# Altium schematic sheet VS1053DEMO.SchDoc
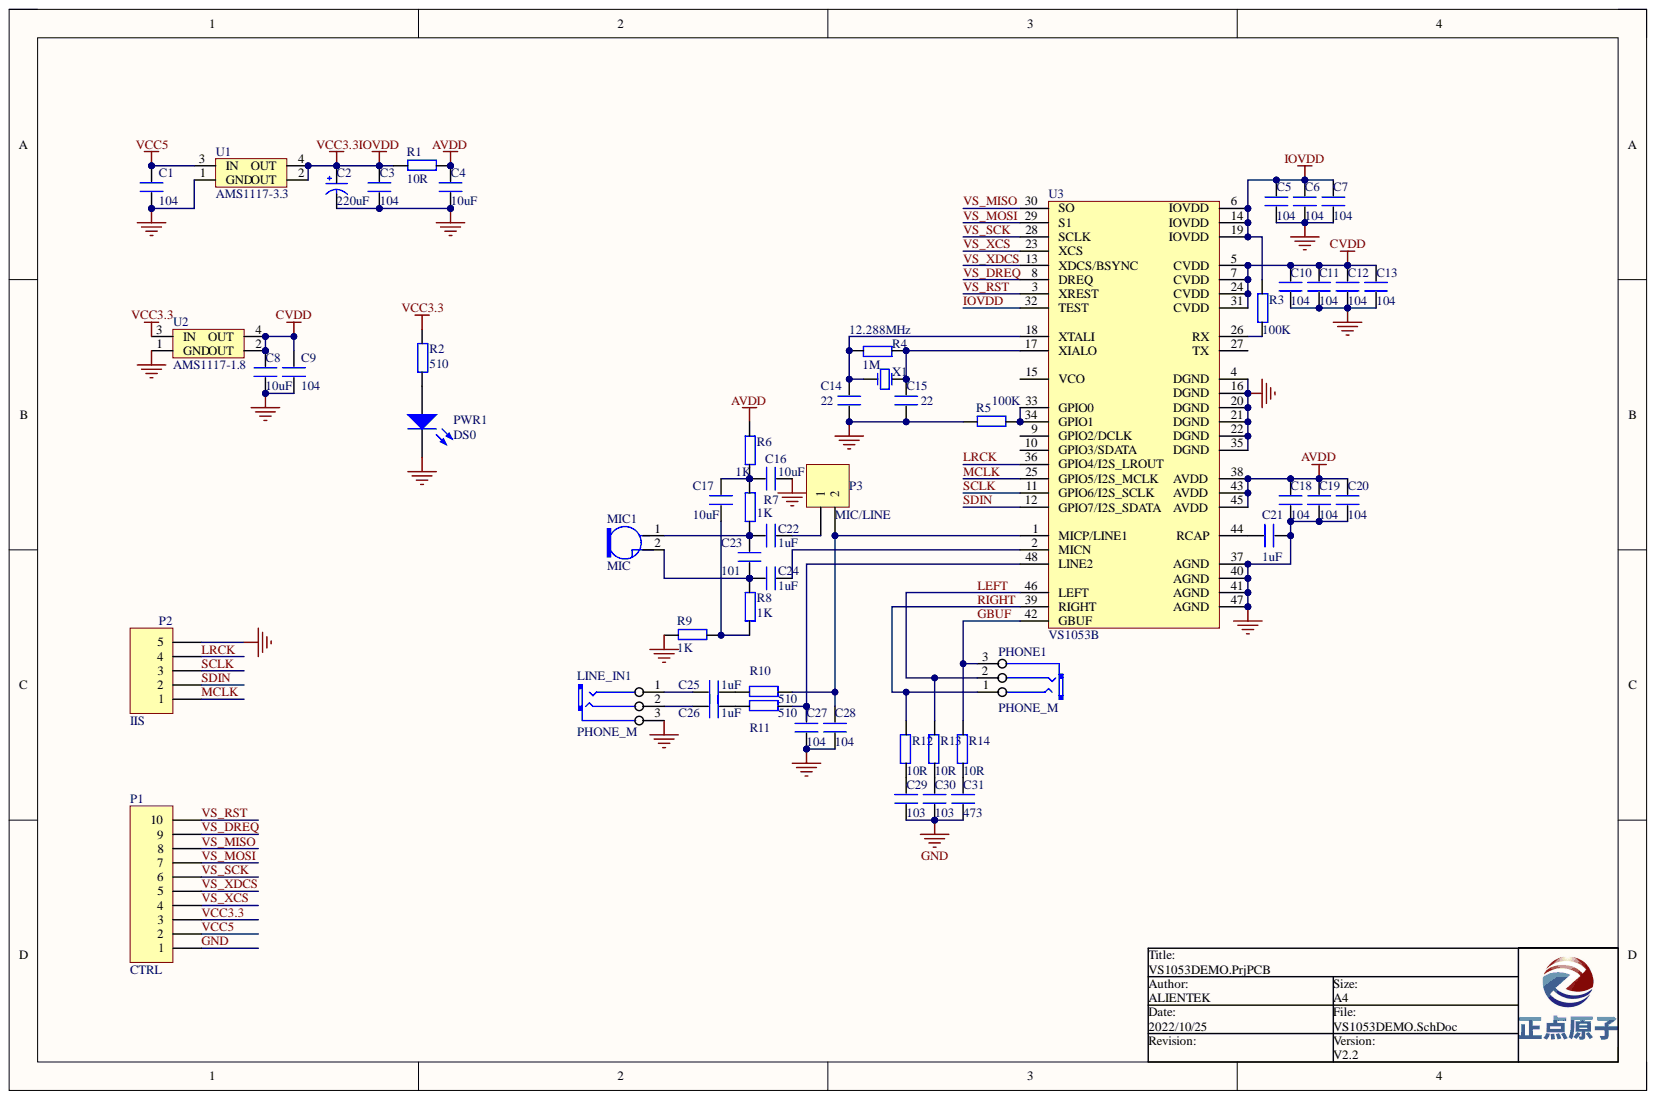
[diagram p0: VS1053DEMO.SchDoc]

## Parts
C1  104  [0603P]
C2  220uF  [CC6.56.5]
C3  104  [0603P]
C4  10uF  [0805]
C5  104  [0603P]
C6  104  [0603P]
C7  104  [0603P]
C8  10uF  [0805]
C9  104  [0603P]
C10  104  [0603P]
C11  104  [0603P]
C12  104  [0603P]
C13  104  [0603P]
C14  22  [0603P]
C15  22  [0603P]
C16  10uF  [0805]
C17  10uF  [0805]
C18  104  [0603P]
C19  104  [0603P]
C20  104  [0603P]
C21  1uF  [0603P]
C22  1uF  [0603P]
C23  101  [0603P]
C24  1uF  [0603P]
C25  1uF  [0603P]
C26  1uF  [0603P]
C27  104  [0603P]
C28  104  [0603P]
C29  103  [0603P]
C30  103  [0603P]
C31  473  [0603P]
LINE_IN1  PHONE_M  [PHONE_ST]
MIC1  MIC  [MIC]
P1  CTRL  [HDR1X10]
P2  IIS  [HDR1X5]
P3  MIC/LINE  [HDR1X2]
PHONE1  PHONE_M  [PHONE_ST]
PWR1  DS0  [SMDLED]
R1  10R  [0603P]
R2  510  [0603P]
R3  100K  [0603P]
R4  1M  [0603P]
R5  100K  [0603P]
R6  1K  [0603P]
R7  1K  [0603P]
R8  1K  [0603P]
R9  1K  [0603P]
R10  510  [0603P]
R11  510  [0603P]
R12  10R  [0603P]
R13  10R  [0603P]
R14  10R  [0603P]
U1  AMS1117-3.3  [AMS1117]
U2  AMS1117-1.8  [AMS1117]
U3  VS1053B  [mTQFP48]
X1  12.288MHz  [XTAL_US]

## Nets
AVDD: C4.1 C18.1 C19.1 C20.1 R1.1 R6.2 U3.38(AVDD) U3.43(AVDD) U3.45(AVDD)
CVDD: C8.1 C9.2 C10.1 C11.1 C12.1 C13.1 U2.2(OUT) U2.4(OUT) U3.5(CVDD) U3.7(CVDD) U3.24(CVDD) U3.31(CVDD)
GBUF: PHONE1.3 R14.1 U3.42(GBUF)
GND: C1.1 C2.2 C3.1 C4.2 C5.2 C6.2 C7.2 C8.2 C9.1 C10.2 C11.2 C12.2 C13.2 C14.1 C15.1 C16.2 C18.2 C19.2 C20.2 C21.1 C27.2 C28.2 C29.2 C30.2 C31.2 LINE_IN1.3 P1.1 P2.5 PWR1.2 R5.2 R9.2 U1.1(GND) U2.1(GND) U3.4(DGND) U3.16(DGND) U3.20(DGND) U3.21(DGND) U3.22(DGND) U3.35(DGND) U3.37(AGND) U3.40(AGND) U3.41(AGND) U3.47(AGND)
IOVDD: C2.1 C3.2 C5.1 C6.1 C7.1 P1.3 R1.2 R2.2 R3.2 U1.2(OUT) U1.4(OUT) U2.3(IN) U3.6(IOVDD) U3.14(IOVDD) U3.19(IOVDD) U3.32(TEST)
LEFT: PHONE1.2 R13.1 U3.46(LEFT)
LRCK: P2.4 U3.36(GPIO4/I2S_LROUT)
MCLK: P2.1 U3.25(GPIO5/I2S_MCLK)
RIGHT: PHONE1.1 R12.1 U3.39(RIGHT)
SCLK: P2.3 U3.11(GPIO6/I2S_SCLK)
SDIN: P2.2 U3.12(GPIO7/I2S_SDATA)
VCC5: C1.2 P1.2 U1.3(IN)
VS_DREQ: P1.9 U3.8(DREQ)
VS_MISO: P1.8 U3.30(SO)
VS_MOSI: P1.7 U3.29(S1)
VS_RST: P1.10 U3.3(XREST)
VS_SCK: P1.6 U3.28(SCLK)
VS_XCS: P1.4 U3.23(XCS)
VS_XDCS: P1.5 U3.13(XDCS/BSYNC)
NetC14_2: C14.2 R4.1 U3.18(XTALI) X1.2
NetC15_2: C15.2 R4.2 U3.17(XIALO) X1.1
NetC16_1: C16.1 C17.1 R6.1 R7.2
NetC17_2: C17.2 R8.1 R9.1
NetC21_2: C21.2 U3.44(RCAP)
NetC22_1: C22.1 P3.1
NetC22_2: C22.2 C23.2 MIC1.1 R7.1
NetC23_1: C23.1 C24.2 MIC1.2 R8.2
NetC24_1: C24.1 U3.2(MICN)
NetC25_1: C25.1 R10.2
NetC25_2: C25.2 LINE_IN1.1
NetC26_1: C26.1 R11.2
NetC26_2: C26.2 LINE_IN1.2
NetC27_1: C27.1 R11.1 U3.48(LINE2)
NetC28_1: C28.1 P3.2 R10.1 U3.1(MICP/LINE1)
NetC29_1: C29.1 R12.2
NetC30_1: C30.1 R13.2
NetC31_1: C31.1 R14.2
NetPWR1_1: PWR1.1 R2.1
NetR3_1: R3.1 U3.26(RX)
NetR5_1: R5.1 U3.33(GPIO0) U3.34(GPIO1)

Not connected: U3 9(GPIO2/DCLK) 10(GPIO3/SDATA) 15(VCO) 27(TX)

## Library data of the parts
C1 C3-C31 = C: Component Kind: Standard; Library Name: MYCOMLIB.IntLib
C2 = CAP: Component Kind: Standard; Library Name: ALIENTEK_COMPONENT.IntLib
P1 = Header 10: Header, 10-Pin; Component Kind: Standard; LatestRevisionDate: 17-Jul-2002; LatestRevisionNote: Re-released for DXP Platform.; Library Name: Miscellaneous Connectors.IntLib; Signal Integrity: Connector; Publisher: Altium Limited
P2 = Header 5: Header, 5-Pin; Component Kind: Standard; LatestRevisionDate: 17-Jul-2002; LatestRevisionNote: Re-released for DXP Platform.; Library Name: Miscellaneous Connectors.IntLib; Signal Integrity: Connector; Publisher: Altium Limited
P3 = Header 2: Header, 2-Pin; Component Kind: Standard; LatestRevisionDate: 17-Jul-2002; LatestRevisionNote: Re-released for DXP Platform.; Library Name: Miscellaneous Connectors.IntLib; Signal Integrity: Connector; Publisher: Altium Limited
PWR1 = LED2: Typical RED, GREEN, YELLOW, AMBER GaAs LED; Component Kind: Standard; LatestRevisionDate: 17-Jul-2002; LatestRevisionNote: Re-released for DXP Platform.; Library Name: Miscellaneous Devices.IntLib; PackageDocument: Feb-2002; PackageReference: 3.2X1.6X1.1; Published: 8-Jun-2000; Simulation: LED2; Publisher: Altium Limited
R1-R14 = R: Component Kind: Standard; Library Name: MYCOMLIB.IntLib
U1 U2 = AMS1117: Component Kind: Standard; Library Name: MYCOMLIB.IntLib
U3 = VS1053: Component Kind: Standard; Library Name: ALIENTEK_COMPONENT.IntLib
X1 = XTAL: Crystal Oscillator; Component Kind: Standard; LatestRevisionDate: 17-Jul-2002; LatestRevisionNote: Re-released for DXP Platform.; Library Name: MYCOMLIB.IntLib; Published: 8-Jun-2000; Publisher: Altium Limited
LINE_IN1 PHONE1 = PHONE_M: Component Kind: Standard; Library Name: ALIENTEK_COMPONENT.IntLib
MIC1 = MIC: Component Kind: Standard; Library Name: MYCOMLIB.IntLib
